# Altium schematic sheet display.SchDoc(display)
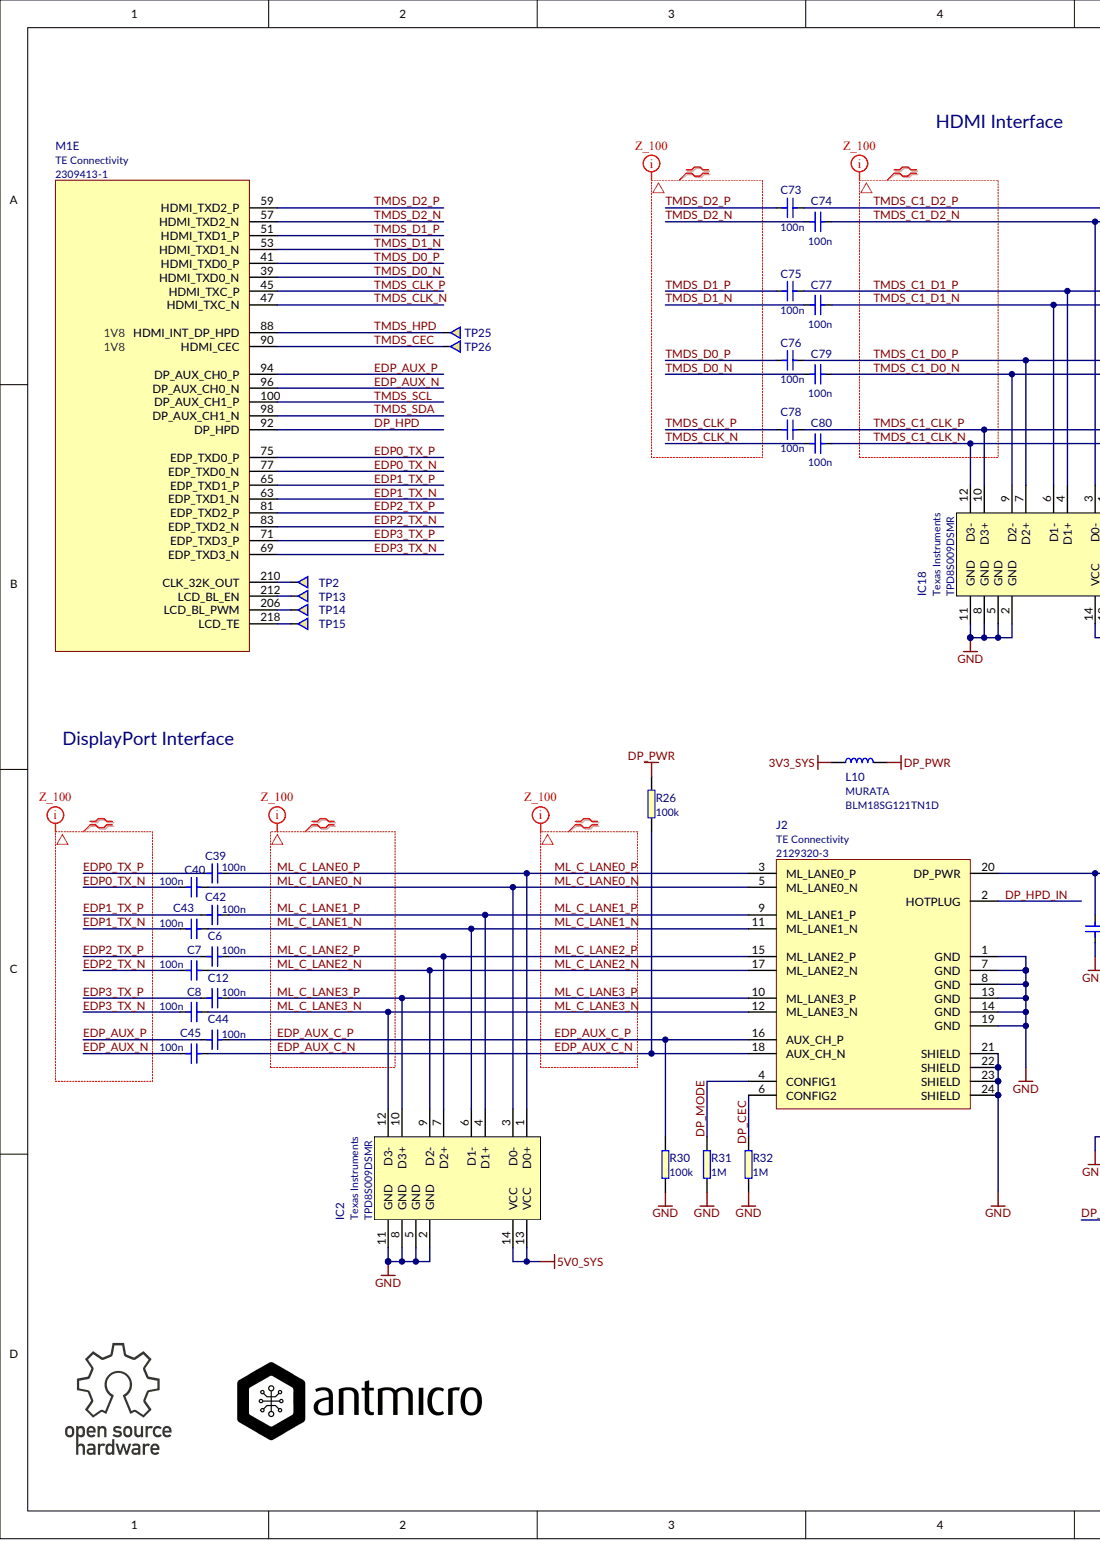
[diagram p0: display.SchDoc, left]
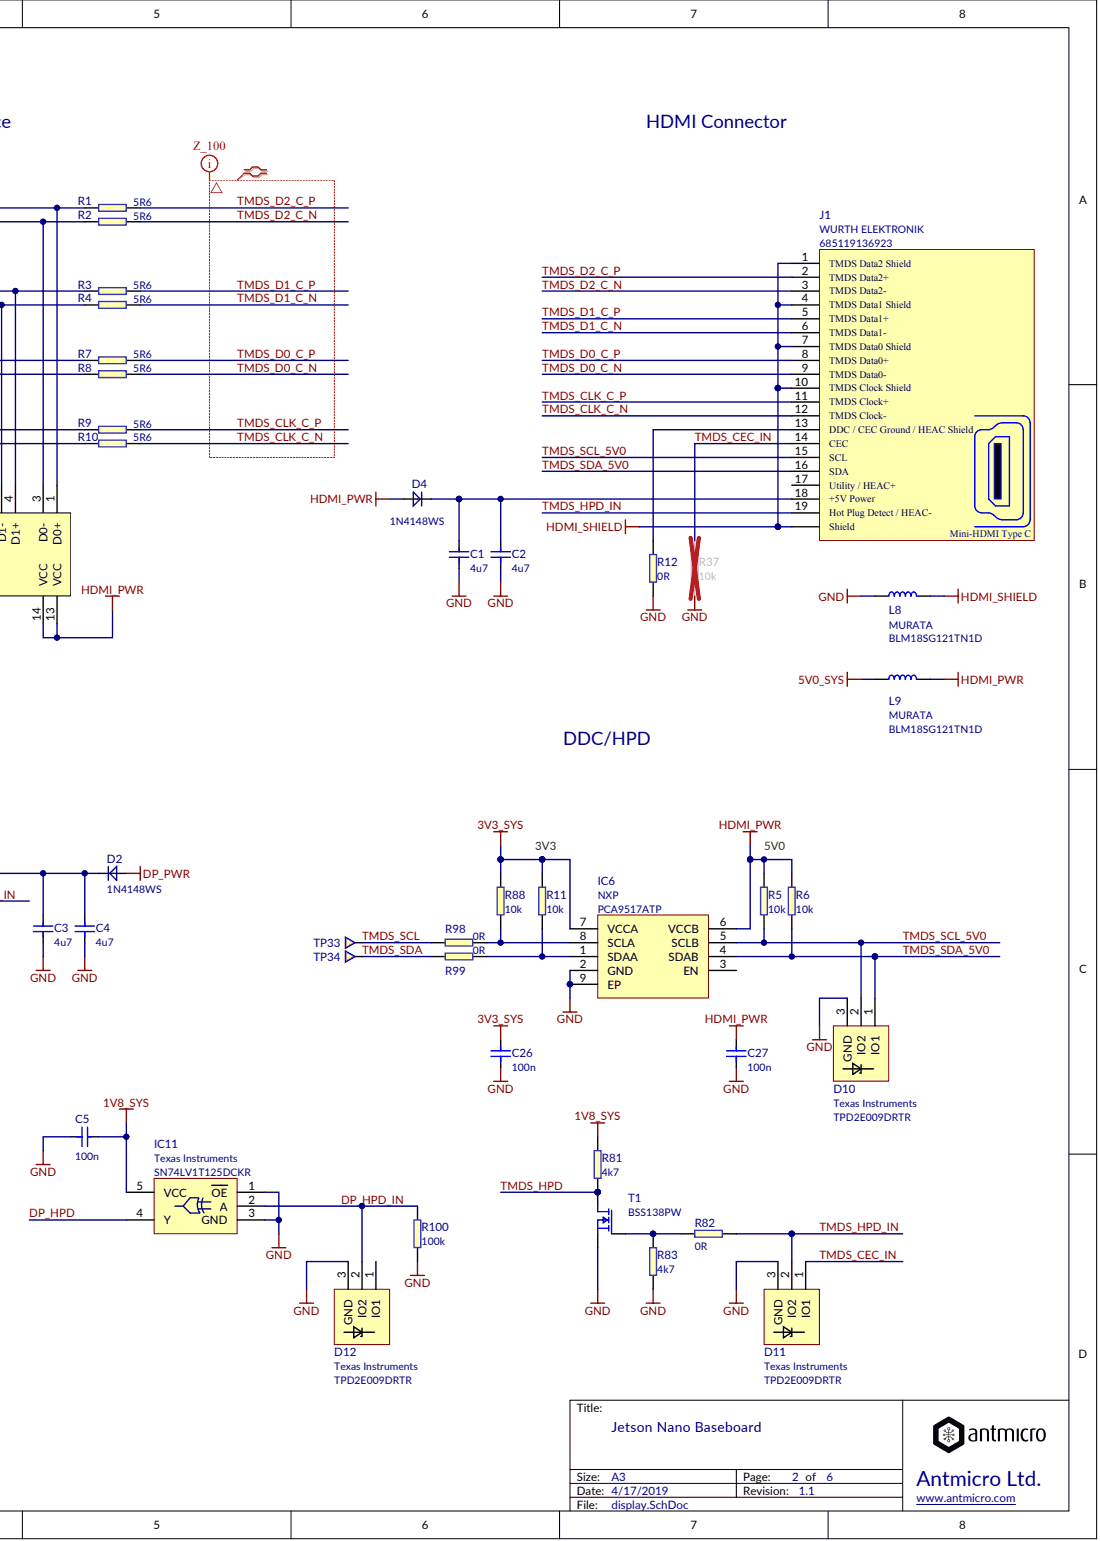
[diagram p1: display.SchDoc, right]

## Parts
C1  4u7
C2  4u7
C3  4u7
C4  4u7
C5  100n
C6  100n
C7  100n
C8  100n
C12  100n
C26  100n
C27  100n
C39  100n
C40  100n
C42  100n
C43  100n
C44  100n
C45  100n
C73  100n  (value not drawn)
C74  100n
C75  100n  (value not drawn)
C76  100n
C77  100n
C78  100n
C79  100n
C80  100n
D2  1N4148WS
D4  1N4148WS
D10  Manufacturer: Texas Instruments  (hidden comment: TPD2E009DRTR)
D11  Manufacturer: Texas Instruments  (hidden comment: TPD2E009DRTR)
D12  Manufacturer: Texas Instruments  (hidden comment: TPD2E009DRTR)
IC2  Manufacturer: Texas Instruments  PartSymbol: TPD8S009DSMR  (hidden comment: TPD8S009)
IC6  Manufacturer: NXP  (hidden comment: PCA9517ATP)
IC11  Manufacturer: Texas Instruments  (hidden comment: SN74LV1T125DCKR)
IC18  Manufacturer: Texas Instruments  PartSymbol: TPD8S009DSMR  (hidden comment: TPD8S009)
J1  Manufacturer: WURTH ELEKTRONIK  (hidden comment: 685119136923)
J2  Manufacturer: TE Connectivity  (hidden comment: 2129320-3)
L8  Manufacturer: MURATA  (hidden comment: BLM18SG121TN1D)
L9  Manufacturer: MURATA  (hidden comment: BLM18SG121TN1D)
L10  Manufacturer: MURATA  (hidden comment: BLM18SG121TN1D)
M1  Manufacturer: TE Connectivity  (hidden comment: 2309413-1)  (part E)
R1  5R6
R2  5R6
R3  5R6
R4  5R6
R5  10k
R6  10k
R7  5R6
R8  5R6
R9  5R6
R10  5R6
R11  10k
R12  0R
R26  100k
R30  100k
R31  1M
R32  1M
R37  10k
R81  4k7
R82  0R
R83  4k7
R88  10k
R98  0R
R99  0R
R100  100k
T1  BSS138PW
TP2  Testpad  (value not drawn)
TP13  Testpad  (value not drawn)
TP14  Testpad  (value not drawn)
TP15  Testpad  (value not drawn)
TP25  Testpad  (value not drawn)
TP26  Testpad  (value not drawn)
TP33  Testpad  (value not drawn)
TP34  Testpad  (value not drawn)

## Nets
1V8_SYS: C5.2 IC11.5(VCC) R81.2
3V3_SYS: C26.2 IC6.7(VCCA) L10.1 R11.2 R88.2
5V0_SYS: IC2.13(VCC) IC2.14(VCC) L9.1
DP_PWR: D2.A L10.2 R26.1
GND: C1.1 C2.1 C3.1 C4.1 C5.1 C26.1 C27.1 D10.3 D11.3 D12.3 IC2.2(GND) IC2.5(GND) IC2.8(GND) IC2.11(GND) IC6.2(GND) IC6.9(EP) IC11.1(OE) IC11.3(GND) IC18.2(GND) IC18.5(GND) IC18.8(GND) IC18.11(GND) J2.1(GND) J2.7(GND) J2.8(GND) J2.13(GND) J2.14(GND) J2.19(GND) J2.21(SHIELD) J2.22(SHIELD) J2.23(SHIELD) J2.24(SHIELD) L8.1 R12.1 R30.1 R31.1 R32.1 R37.1 R83.1 R100.2 T1.2
HDMI_PWR: C27.2 D4.A IC6.6(VCCB) IC18.13(VCC) IC18.14(VCC) L9.2 R5.2 R6.2
HDMI_SHIELD: J1.1(TMDS_Data2_Shield) J1.4(TMDS_Data1_Shield) J1.7(TMDS_Data0_Shield) J1.10(TMDS_Clock_Shield) J1.Shell(Shield) L8.2
DP_HPD: IC11.4(Y) M1.92(DP_HPD)
DP_HPD_IN: D12.2 IC11.2(A) J2.2(HOTPLUG) R100.1
DP_MODE: J2.4(CONFIG1) R31.2
EDP0_TX_N: C40.1 M1.77(EDP_TXD0_N)
EDP0_TX_P: C39.1 M1.75(EDP_TXD0_P)
EDP1_TX_N: C43.1 M1.63(EDP_TXD1_N)
EDP1_TX_P: C42.1 M1.65(EDP_TXD1_P)
EDP2_TX_N: C7.1 M1.83(EDP_TXD2_N)
EDP2_TX_P: C6.1 M1.81(EDP_TXD2_P)
EDP3_TX_N: C12.1 M1.69(EDP_TXD3_N)
EDP3_TX_P: C8.1 M1.71(EDP_TXD3_P)
EDP_AUX_C_N: C45.2 J2.18(AUX_CH_N) R26.2
EDP_AUX_C_P: C44.2 J2.16(AUX_CH_P) R30.2
EDP_AUX_N: C45.1 M1.96(DP_AUX_CH0_N)
EDP_AUX_P: C44.1 M1.94(DP_AUX_CH0_P)
ML_C_LANE0_N: C40.2 IC2.3(D0-) J2.5(ML_LANE0_N)
ML_C_LANE0_P: C39.2 IC2.1(D0+) J2.3(ML_LANE0_P)
ML_C_LANE1_N: C43.2 IC2.6(D1-) J2.11(ML_LANE1_N)
ML_C_LANE1_P: C42.2 IC2.4(D1+) J2.9(ML_LANE1_P)
ML_C_LANE2_N: C7.2 IC2.9(D2-) J2.17(ML_LANE2_N)
ML_C_LANE2_P: C6.2 IC2.7(D2+) J2.15(ML_LANE2_P)
ML_C_LANE3_N: C12.2 IC2.12(D3-) J2.12(ML_LANE3_N)
ML_C_LANE3_P: C8.2 IC2.10(D3+) J2.10(ML_LANE3_P)
TMDS_C1_CLK_N: C80.2 IC18.12(D3-) R10.1
TMDS_C1_CLK_P: C78.2 IC18.10(D3+) R9.1
TMDS_C1_D0_N: C79.2 IC18.9(D2-) R8.1
TMDS_C1_D0_P: C76.2 IC18.7(D2+) R7.1
TMDS_C1_D1_N: C77.2 IC18.6(D1-) R4.1
TMDS_C1_D1_P: C75.2 IC18.4(D1+) R3.1
TMDS_C1_D2_N: C74.2 IC18.3(D0-) R2.1
TMDS_C1_D2_P: C73.2 IC18.1(D0+) R1.1
TMDS_CEC: M1.90(HDMI_CEC) TP26.1
TMDS_CEC_IN: D11.1 J1.14(CEC) R37.2
TMDS_CLK_C_N: J1.12(TMDS_Clock-) R10.2
TMDS_CLK_C_P: J1.11(TMDS_Clock+) R9.2
TMDS_CLK_N: C80.1 M1.47(HDMI_TXC_N)
TMDS_CLK_P: C78.1 M1.45(HDMI_TXC_P)
TMDS_D0_C_N: J1.9(TMDS_Data0-) R8.2
TMDS_D0_C_P: J1.8(TMDS_Data0+) R7.2
TMDS_D0_N: C79.1 M1.39(HDMI_TXD0_N)
TMDS_D0_P: C76.1 M1.41(HDMI_TXD0_P)
TMDS_D1_C_N: J1.6(TMDS_Data1-) R4.2
TMDS_D1_C_P: J1.5(TMDS_Data1+) R3.2
TMDS_D1_N: C77.1 M1.53(HDMI_TXD1_N)
TMDS_D1_P: C75.1 M1.51(HDMI_TXD1_P)
TMDS_D2_C_N: J1.3(TMDS_Data2-) R2.2
TMDS_D2_C_P: J1.2(TMDS_Data2+) R1.2
TMDS_D2_N: C74.1 M1.57(HDMI_TXD2_N)
TMDS_D2_P: C73.1 M1.59(HDMI_TXD2_P)
TMDS_HPD: M1.88(HDMI_INT_DP_HPD) R81.1 T1.3 TP25.1
TMDS_HPD_IN: D11.2 J1.19(Hot_Plug_Detect_/_HEAC-) R82.1
TMDS_SCL: M1.100(DP_AUX_CH1_P) R98.2 TP33.1
TMDS_SCL_5V0: D10.2 IC6.5(SCLB) J1.15(SCL) R5.1
TMDS_SDA: M1.98(DP_AUX_CH1_N) R99.2 TP34.1
TMDS_SDA_5V0: D10.1 IC6.4(SDAB) J1.16(SDA) R6.1
NetC1_2: C1.2 C2.2 D4.C J1.18(+5V_Power)
NetC3_2: C3.2 C4.2 D2.C J2.20(DP_PWR)
NetIC6_1: IC6.1(SDAA) R11.1 R99.1
NetIC6_8: IC6.8(SCLA) R88.1 R98.1
NetJ1_13: J1.13(DDC_/_CEC_Ground_/_HEAC_Shield) R12.2
NetJ2_6: J2.6(CONFIG2) R32.2
NetM1_206: M1.206(LCD_BL_PWM) TP14.1
NetM1_210: M1.210(CLK_32K_OUT) TP2.1
NetM1_212: M1.212(LCD_BL_EN) TP13.1
NetM1_218: M1.218(LCD_TE) TP15.1
NetR82_2: R82.2 R83.2 T1.1
DP_CEC:
N00170:
N00171:
N00172:
N00173:
N00174:
N00175:
N00176:
N00177:
N00178:
N00179:
N00180:
N00181:

Not connected: D12 1; IC6 3(EN); J1 17(Utility_/_HEAC+)

## Library data of the parts
C1-C4 = (no symbol name): PartNumber: C_4u7_0402; Manufacturer: MURATA; PartSymbol: GRM155R60G475ME; Value: 4u7
C5 C26 C27 = (no symbol name): Manufacturer: AVX; PartNumber: C_100n_0603; PartSymbol: 0603YC104KAZ2A; Value: 100n
C6-C8 C12 C39 C40 C42-C45 C73-C80 = (no symbol name): Manufacturer: TDK; PartNumber: C_100n_0402; PartSymbol: C1005X5R0J104K050BA; Value: 100n
D2 D4 = (no symbol name): Manufacturer: ON SEMICONDUCTOR; Value: 1N4148WS; PartNumber: 1N4148WS; PartSymbol: 1N4148WS
J1 = (no symbol name): PartNumber: WE_685119136923; Value: 685119136923; PartSymbol: WE_685119136923
R1-R4 R7-R10 = (no symbol name): PartNumber: R_5R6_0402; Manufacturer: Panasonic; PartSymbol: ERJ2GEJ5R6X; Value: 5R6
R5 R6 R11 R88 = (no symbol name): Manufacturer: BOURNS; PartNumber: R_10k_0603; PartSymbol: CR0603-JW-103ELF; Value: 10k
R12 R82 R98 R99 = (no symbol name): PartNumber: R_0R_0402; Manufacturer: Panasonic; PartSymbol: ERJ2GE0R00X; Value: 0R
R26 R30 R100 = (no symbol name): Manufacturer: BOURNS; PartNumber: R_100k_0603; PartSymbol: CR0603-FX-1003HLF; Value: 100k
R31 R32 = (no symbol name): Manufacturer: BOURNS; PartNumber: R_1M_0603; PartSymbol: CR0603-JW-105GLF; Value: 1M
R37 = (no symbol name): Manufacturer: MULTICOMP; PartNumber: R_10k_0402; PartSymbol: MCMR04X1002FTL; Value: 10k
R81 R83 = (no symbol name): Manufacturer: BOURNS; PartNumber: R_4k7_0603; PartSymbol: CR0603-FX-4701ELF; Value: 4k7
T1 = (no symbol name): Manufacturer: NXP; Value: BSS138PW; PartNumber: BSS138PW; PartSymbol: BSS138PW
TP2 TP13-TP15 TP25 TP26 TP33 TP34 = (no symbol name): PartNumber: TP-R-1.5
D10-D12 IC2 IC6 IC11 IC18 J2 L8-L10 M1 = (no symbol name)
  D10-D12: Value: TPD2E009DRTR; PartNumber: TPD2E009DRTR; PartSymbol: TPD2E009DRTR
  IC2 IC18: Value: TPD8S009; PartNumber: TPD8S009
  IC6: Value: PCA9517ATP; PartNumber: PCA9517ATP; PartSymbol: PCA9517ATP
  IC11: PartNumber: SN74LV1T125DCKR; Value: SN74LV1T125DCKR; PartSymbol: SN74LV1T125DCKR
  J2: PartNumber: 2129320-3; Value: 2129320-3; PartSymbol: 2129320-3
  L8-L10: Value: BLM18SG121TN1D; PartNumber: BLM18SG121TN1D; PartSymbol: BLM18SG121TN1D
  M1: PartNumber: 2309413-1; Value: 2309413-1; PartSymbol: 2309413-1
